# Altium schematic sheet DesignChallenge1.SchDoc
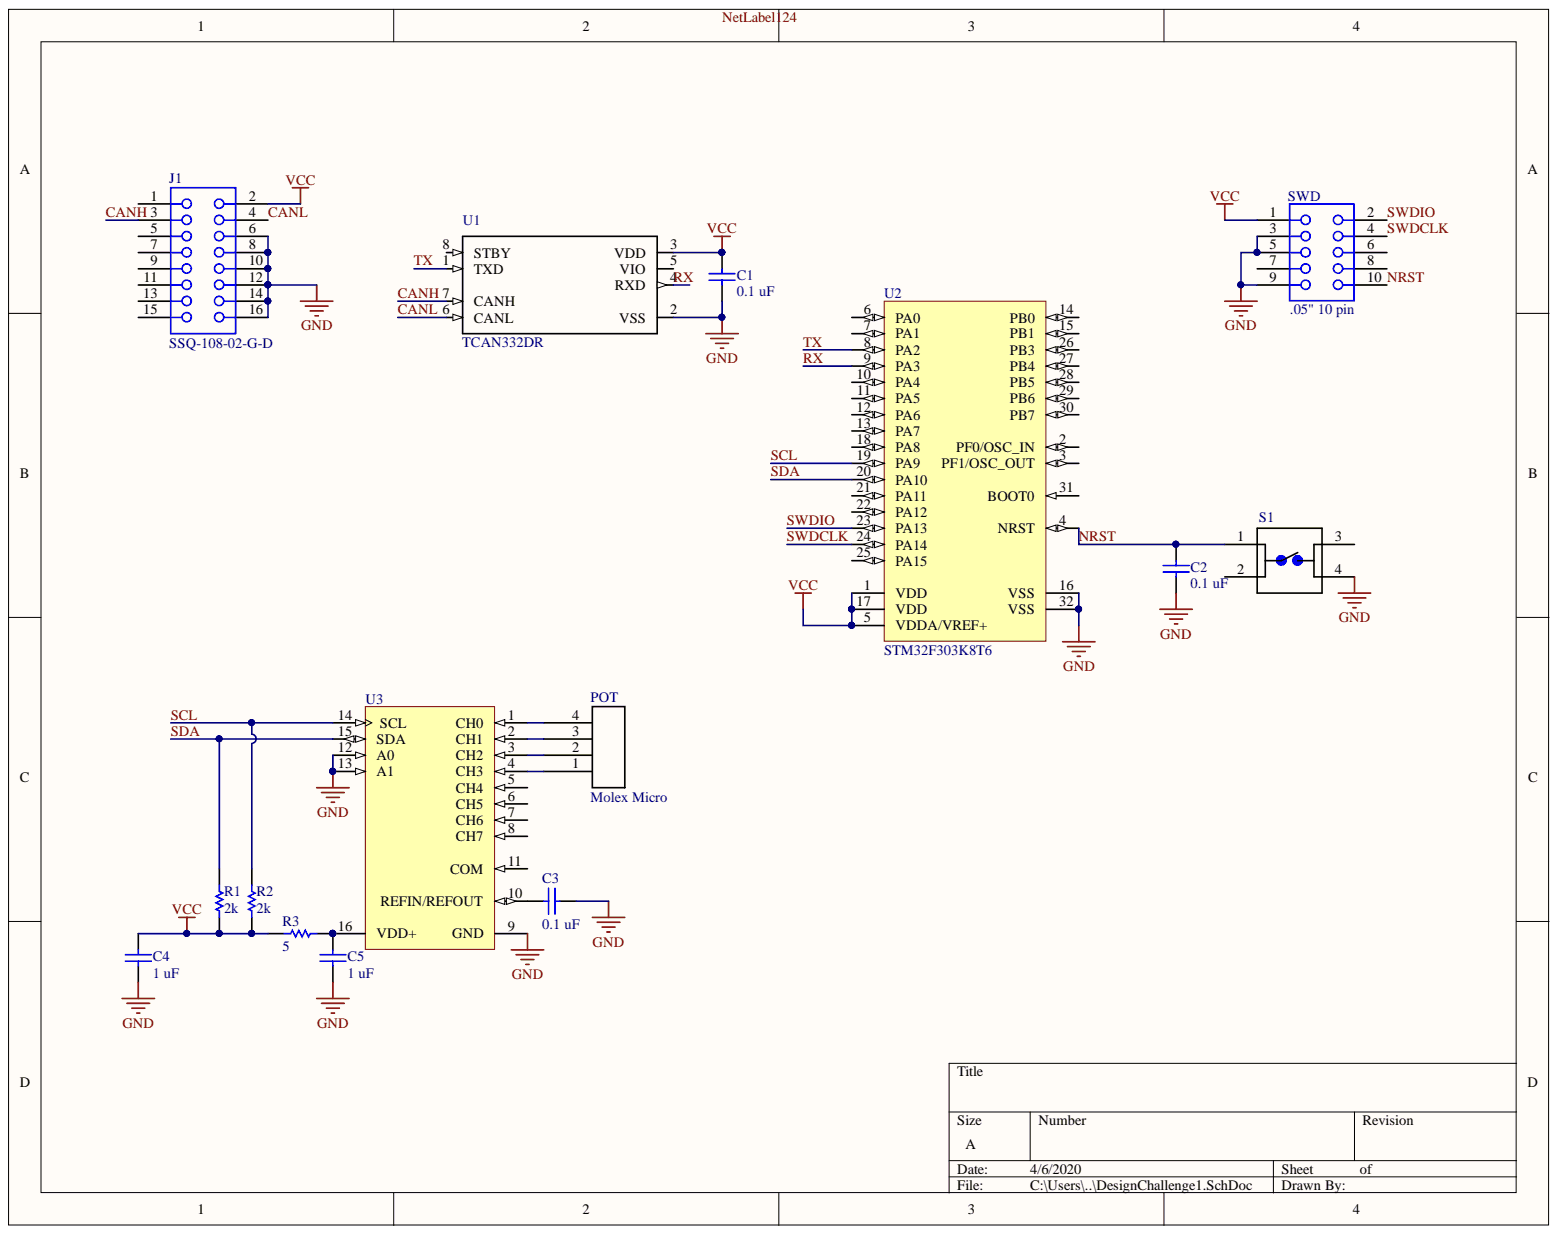
[diagram p0: DesignChallenge1.SchDoc]

## Parts
C1  0.1 uF  [CAPC0805(2012)145_N]
C2  0.1 uF  [CAPC0805(2012)145_N]
C3  0.1 uF  [CAPC0805(2012)145_N]
C4  1 uF  [CAPC0805(2012)145_N]
C5  1 uF  [CAPC0805(2012)145_N]
J1  SSQ-108-02-G-D  [SMTC-SSQ-108-02-X-D]
POT  Molex Micro  [0430450427]
R1  2k  [RESC0805(2012)_N]
R2  2k  [RESC0805(2012)_N]
R3  5  [RESC0805(2012)_N]
S1  1825910-7  [*SW_1825910-7]  (value not drawn)
SWD  .05" 10 pin  [PCE-FH127H432-10-SNGRH-2.4]
U1  TCAN332DR  [Model Name]
U2  STM32F303K8T6  [STM-LQFP32_M]
U3  (no value)  [TI-PW16_M]

## Nets
GND: C1.1 C2.1 C3.2 C4.1 C5.1 J1.6 J1.8 J1.10 J1.12 J1.14 J1.16 S1.4 SWD.3 SWD.5 SWD.9 U1.2(VSS) U2.16(VSS) U2.32(VSS) U3.9(GND) U3.12(A0) U3.13(A1)
VCC: C1.2 C4.2 J1.2 R1.2 R2.2 R3.2 SWD.1 U1.3(VDD) U2.1(VDD) U2.5(VDDA/VREF+) U2.17(VDD)
CANH: J1.3 U1.7(CANH)
CANL: J1.4 U1.6(CANL)
NRST: C2.2 S1.1 SWD.10 U2.4(NRST)
RX: U1.4(RXD) U2.9(PA3)
SCL: R2.1 U2.19(PA9) U3.14(SCL)
SDA: R1.1 U2.20(PA10) U3.15(SDA)
SWDCLK: SWD.4 U2.24(PA14)
SWDIO: SWD.2 U2.23(PA13)
TX: U1.1(TXD) U2.8(PA2)
NetC3_1: C3.1 U3.10(REFIN/REFOUT)
NetC5_2: C5.2 R3.1 U3.16(VDD+)
NetPOT_1: POT.1 U3.4(CH3)
NetPOT_2: POT.2 U3.3(CH2)
NetPOT_3: POT.3 U3.2(CH1)
NetPOT_4: POT.4 U3.1(CH0)
NetLabel124:

Not connected: J1 1 5 7 9 11 13 15; S1 2 3; SWD 6 7 8; U1 5(VIO) 8(STBY); U2 2(PF0/OSC_IN) 3(PF1/OSC_OUT) 6(PA0) 7(PA1) 10(PA4) 11(PA5) 12(PA6) 13(PA7) 14(PB0) 15(PB1) 18(PA8) 21(PA11) 22(PA12) 25(PA15) 26(PB3) 27(PB4) 28(PB5) 29(PB6) 30(PB7) 31(BOOT0); U3 5(CH4) 6(CH5) 7(CH6) 8(CH7) 11(COM)

## Library data of the parts
C1-C5 = CMP-1036-02677-1: CAP 470pF 100V ±2% 0805 (2012 Metric) Thickness 1.45mm SMD; Case-EIA: 0805; Case-Metric: 2012; Tolerance: Â±2%; Component Kind: Standard; Library Name: Altium Content Vault; Max Thickness: 1.45 mm; Rated Voltage: 100 V; Technology: SMT; Value: 470pF
J1 = CMP-1023-00300-1: SQ Post Socket, Through-hole, Vertical, -55 to 125 degC, 2.54 mm Pitch, 16-Pin, Female, RoHS; Manufacturer: Samtec; Pitch: 2.54 mm; Component Kind: Standard; ComponentLink1Description: Manufacturer URL; ComponentLink1URL: http://www.samtec.com; ComponentLink2Description: Datasheet; ComponentLink2URL: http://www.samtec.com/documents/webfiles/pdf/SSQ_TH.PDF; ComponentLink3Description: Package Specification; ComponentLink3URL: http://www.samtec.com/documents/webfiles/cpdf/SSQ-1XX-XX-XXX-X-XX-XXX-XX-X-MKT.pdf; DatasheetVersion: F-214; Library Name: Headers.SchLib; Mounting Technology: Through-hole; Orientation: Vertical; PackageDescription: Assembly, Socket Strip .025[0.64] SQ Tail; PackageReference: SSQ-108-02-X-D; PackageVersion: BD, 6/1987; PartNumber: SSQ-108-02-G-D; RoHS: True; Temperature Range: -55 to 125 degC
POT = Molex micro-fit 4-pin (Single row schematic): Molex micro-fit 3.0 dual row header. P/N 0430450427; Component Kind: Standard; Library Name: Connector Schematic Symbols.SchLib
R1-R3 = CMP-1013-00418-1: 160R 0.125W 1% 0805 (2012 Metric)  SMD; Case-EIA: 0805; Case-Metric: 2012; Value: 160.00 Ohm; Component Kind: Standard; Library Name: Altium Content Vault; Power: 0.125 W; Technology: SMT; Tolerance: 1 %
S1 = 1825910-7: FSM4JAH=6MM TACT SWITCH, HIGH TEMP; Configuration_Pole-Throw: Single Pole - Single Throw; Contact_Current_Rating: 50 mA; MF: TE Connectivity; Availability: Unavailable; Component Kind: Standard; EU_RoHS_Compliance: Compliant; Library Name: 1825910-7.IntLib; MP: 1825910-7; Package: None; Price: None; SnapEDA_Link: https://www.snapeda.com/parts/1825910-7/TE%20Connectivity%20ALCOSWITCH%20Switches/view-part/?ref=snap; TE_purchase_URL: https://www.te.com/usa-en/product-1825910-7.html?te_bu=Cor&te_type=disp&te_campaign=seda_glo_cor-seda-global-disp-prtnr-fy19-seda-model-bom-cta_sma-317_1&elqCampaignId=32493
SWD = CMP-0594-00001-1: Female Header, Pitch 1.27 mm, 2x5 Position, Height 4.3 mm, Tail Length 2.4 mm; Manufacturer: Pinchao Electronic; PartNumber: FH127H432-10-SNGRH-2.4; Current Rating: 2 Amps; Dielectric Withstaanding: AC 500 V; Insulator Material: NY-6T; Insulator resistance (Min.): 5000 Megohms; No. of positions: 10; No. of Rows: 2; Pitch: 1.27 mm; Plating: Gold Flash; Component Kind: Standard; ComponentLink1Description: Manufacturer URL; ComponentLink1URL: http://www.suzhoupinchao.com/; Connector Type: Female Header; Library Name: Altium Content Vault; Mounting Technology: Through Hole; Operating Temperature: -40 to 105 degC; PackageDescription: Female Header, Pitch 1.27 mm, 2x5 Position, Height 4.3 mm, Tail Length 2.4 mm; PackageReference: FH127H432-10-SNGRH-2.4
U1 = CAN Tranceiver: Microchip MCP2562-E/SN, CAN Transceiver 1MBps 1-channel IEC 61000-4-2, 8-Pin SOIC; Availability: Unavailable; Component Kind: Standard; Library Name: CAN Tranceiver.SchLib; MF: Microchip; MP: MCP2562-E/SN; Package: SO-8 Microchip; Price: None; SnapEDA_Link: https://www.snapeda.com/parts/MCP2562-E/SN/Microchip/view-part/?ref=snap
U2 = CMP-0537-00040-1: ARM Cortex-M4 32-bit MCU, 64 KB Flash, 16 KB Internal RAM, 25 I/Os, 32-Pin LQFP, -40 to 85 degC, Tray; D/A Converter: 3; FLASH Size (Prog) (kB): 64; I/Os (High Current): 25; Internal RAM Size (kB): 16; Operating Frequency(F) (Processor speed) (MHz): 72; Supply Voltage(Vcc) min (V): 2; Component Kind: Standard; ComponentLink1Description: Manufacturer URL; ComponentLink1URL: http://www.st.com/; ComponentLink2Description: Datasheet; ComponentLink2URL: http://www.st.com/st-web-ui/static/active/en/resource/technical/document/datasheet/DM00092070.pdf; Core: ARM Cortex-M4; DatasheetVersion: Rev. 4; Library Name: Altium Content Vault; Mounting Technology: Surface Mount; Package Description: 32-Pin Low Profile Quad Flat Package, 7 x 7 mm Body, 0.8 mm Pitch; Package Reference: LQFP32; Packing: Tray
U3 = CMP-0003-00799-1: 12-Bit 50 kSPS ADC I2C Low Power 8-Channel Multiplexer Internal 2.5 V Reference, -40 to 85 degC, 16-pin SOP (PW16), Green (RoHS & no Sb/Br); Manufacturer: Texas Instruments; PartNumber: ADS7828EB/250; # Input Channels: 8; Analog Voltage AV/DD(Max)(V): 5.25; Analog Voltage AV/DD(Min)(V): 2.7; Architecture: SAR; INL(Max)(+/-LSB): 1; Interface: Serial I2C; Resolution(Bits): 12; Sample Rate (max)(SPS): 50k; SFDR(dB): 86; SINAD(dB): 71; SNR(dB): 72; SubFamily: Precision ADC (<=10MSPS); Code_JEDEC: MO-153; Component Kind: Standard; ComponentLink1Description: Manufacturer URL; ComponentLink1URL: http://www.ti.com/; ComponentLink2Description: Datasheet; ComponentLink2URL: http://www.ti.com/general/docs/lit/getliterature.tsp?genericPartNumber=ADS7828&fileType=pdf; ComponentLink3Description: Package Specification; ComponentLink3URL: http://www.ti.com/lit/ml/mpds361/mpds361.pdf; ComponentLink4Description: Better Datasheet; ComponentLink4URL: http://www.ti.com/lit/ds/symlink/ads7828-q1.pdf; DatasheetVersion: SBAS181C; Digital Supply(Max)(V): 5.25; Digital Supply(Min)(V): 2.7; Eco-Plan: Green (RoHS & no Sb/Br); Library Name: Altium Content Vault; Mounting Technology: Surface Mount; PackageDescription: 16-Pin Plastic Small Outline, Body 5 x 4.4 mm, Pitch 0.65 mm; PackageReference: PW16; PackageVersion: 4040064-4/G, 02/2011
